# Altium schematic sheet LCD_3.5.SchDoc(LCD_3.5)
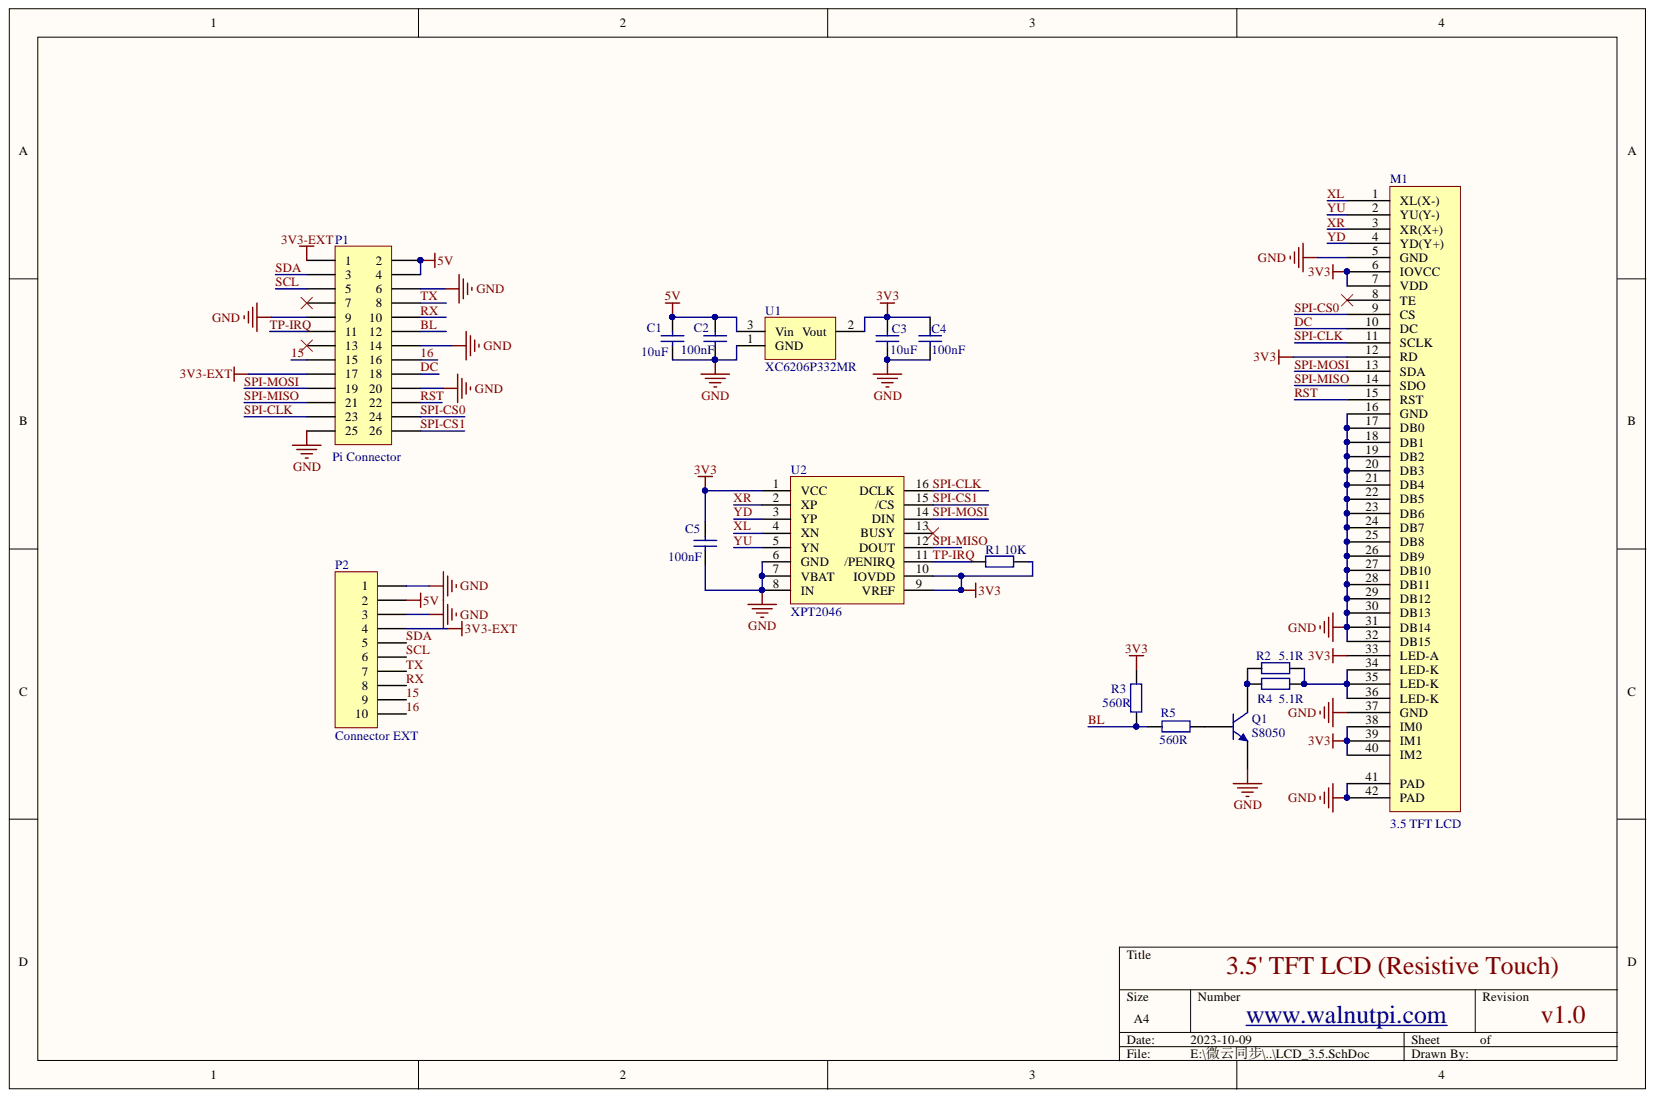
[diagram p0: LCD_3.5.SchDoc]

## Parts
C1  10uF  [R0603]
C2  100nF  [R0603]
C3  10uF  [R0603]
C4  100nF  [R0603]
C5  100nF  [R0603]
M1  3.5 TFT LCD  [3.5-QD3503]
P1  Pi Connector  [HDR2X13]
P2  Connector EXT  [1x10卧式贴片排针]
Q1  S8050  [SOT-23]
R1  10K  [R0603]
R2  5.1R  [R0603]
R3  560R  [R0603]
R4  5.1R  [R0603]
R5  560R  [R0603]
U1  XC6206P332MR  [SOT-23]
U2  XPT2046  [TSSOP-16]

## Nets
3V3: C3.2 C4.2 C5.2 M1.6(IOVCC) M1.7(VDD) M1.12(RD) M1.33(LED-A) M1.38(IM0) M1.39(IM1) M1.40(IM2) R1.2 R3.2 U1.2 U2.1(VCC) U2.9(VREF) U2.10(IOVDD)
3V3-EXT: P1.1 P1.17 P2.4
5V: C1.2 C2.2 P1.2 P1.4 P2.2 U1.3
GND: C1.1 C2.1 C3.1 C4.1 C5.1 M1.5(GND) M1.16(GND) M1.17(DB0) M1.18(DB1) M1.19(DB2) M1.20(DB3) M1.21(DB4) M1.22(DB5) M1.23(DB6) M1.24(DB7) M1.25(DB8) M1.26(DB9) M1.27(DB10) M1.28(DB11) M1.29(DB12) M1.30(DB13) M1.31(DB14) M1.32(DB15) M1.37(GND) M1.41(PAD) M1.42(PAD) P1.6 P1.9 P1.14 P1.20 P1.25 P2.1 P2.3 Q1.2 U1.1 U2.6(GND) U2.7(VBAT) U2.8(IN)
15: P1.15 P2.9
16: P1.16 P2.10
BL: P1.12 R3.1 R5.1
DC: M1.10(DC) P1.18
RST: M1.15(RST) P1.22
RX: P1.10 P2.8
SCL: P1.5 P2.6
SDA: P1.3 P2.5
SPI-CLK: M1.11(SCLK) P1.23 U2.16(DCLK)
SPI-CS0: M1.9(CS) P1.24
SPI-CS1: P1.26 U2.15(/CS)
SPI-MISO: M1.14(SDO) P1.21 U2.12(DOUT)
SPI-MOSI: M1.13(SDA) P1.19 U2.14(DIN)
TP-IRQ: P1.11 R1.1 U2.11(/PENIRQ)
TX: P1.8 P2.7
XL: M1.1(XL(X-)) U2.4(XN)
XR: M1.3(XR(X+)) U2.2(XP)
YD: M1.4(YD(Y+)) U2.3(YP)
YU: M1.2(YU(Y-)) U2.5(YN)
NetM1_34: M1.34(LED-K) M1.35(LED-K) M1.36(LED-K) R2.2 R4.2
NetQ1_1: Q1.1 R5.2
NetQ1_3: Q1.3 R2.1 R4.1

Not connected: M1 8(TE); P1 7 13; U2 13(BUSY)

## Library data of the parts
C1-C4 = Cap: Capacitor; Simulation: CAP; Signal Integrity: Cap; Published: 8-Jun-2000; PackageReference: RAD-0.3; Library Name: Miscellaneous Devices.IntLib; LatestRevisionNote: Re-released for DXP Platform.; LatestRevisionDate: 17-Jul-2002; Component Kind: Standard; Publisher: Altium Limited
C5 = Cap1: Library Name: Main.SchLib; Component Kind: Standard
M1 = QD3503: 3.5 inch TFT LCD; Library Name: Schlib1.SchLib; Component Kind: Standard
P1 = Header 13X2: Header, 13-Pin, Dual row; Signal Integrity: Connector; Library Name: Miscellaneous Connectors.IntLib; LatestRevisionNote: Re-released for DXP Platform.; LatestRevisionDate: 17-Jul-2002; Component Kind: Standard; Publisher: Altium Limited
P2 = Header 10: Header, 10-Pin; Signal Integrity: Connector; Library Name: Miscellaneous Connectors.IntLib; LatestRevisionNote: Re-released for DXP Platform.; LatestRevisionDate: 17-Jul-2002; Component Kind: Standard; Publisher: Altium Limited
R1-R5 = Res2: Resistor; Simulation: RESISTOR; Signal Integrity: Res; Published: 8-Jun-2000; PackageReference: AXIAL-0.4; Library Name: Miscellaneous Devices.IntLib; LatestRevisionNote: Re-released for DXP Platform.; LatestRevisionDate: 17-Jul-2002; Component Kind: Standard; Publisher: Altium Limited
Q1 = S8050: Library Name: WeBee.SCHLIB; Component Kind: Standard
U1 = XC6206P332MR: Library Name: WeBee.SCHLIB; Component Kind: Standard
U2 = XPT2046: Library Name: Main.SchLib; Component Kind: Standard
